# Altium schematic sheet EXT_IO.SchDoc(EXT_IO)
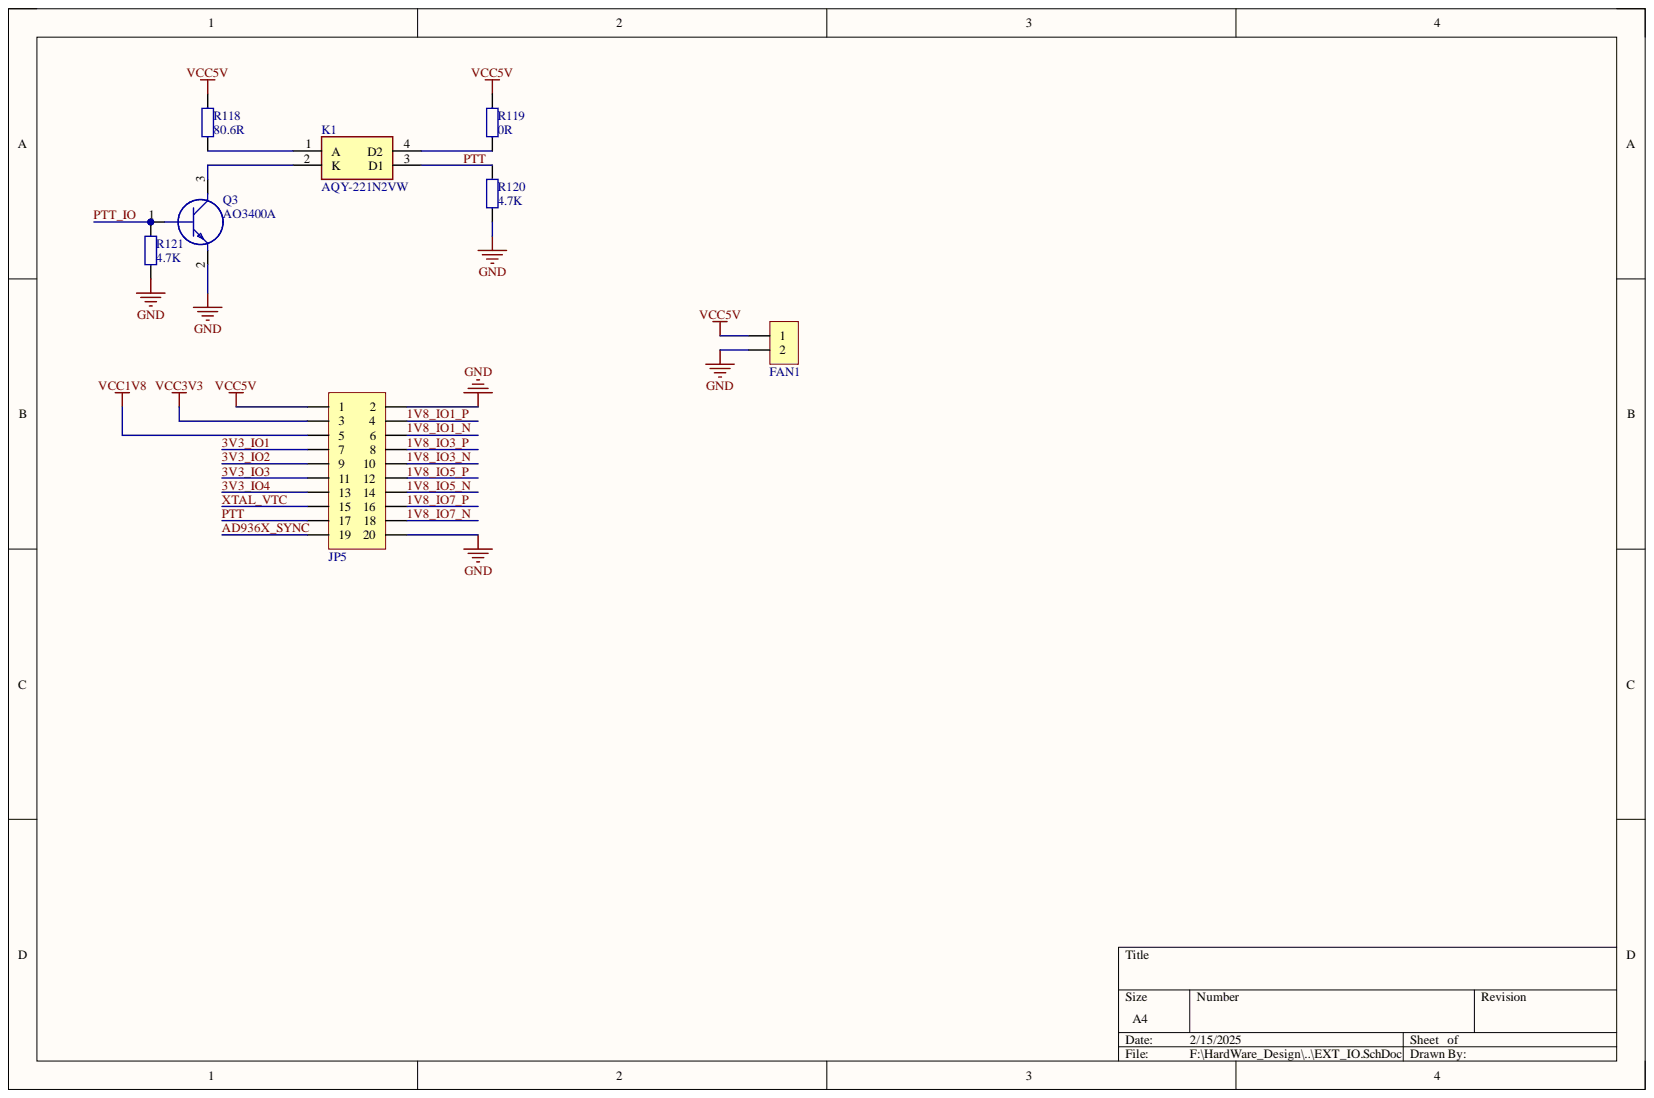
[diagram p0: EXT_IO.SchDoc]

## Parts
FAN1  HDR-1X2  [ZH1.5-LI-2p]  (value not drawn)
JP5  Header 2X10  [HDR2.54-LI-2x10P]  (value not drawn)
K1  AQY-221N2VW  [AQY221N2VW]
Q3  AO3400A  [SOT95P240X110-3N]
R118  80.6R  [R 0402_L]
R119  0R  [R 0402_L]
R120  4.7K  [R 0402_L]
R121  4.7K  [R 0402_L]

## Nets
GND: FAN1.2 JP5.2 JP5.20 Q3.2 R120.1 R121.1
VCC1V8: JP5.5
VCC3V3: JP5.3
VCC5V: FAN1.1 JP5.1 R118.2 R119.2
1V8_IO1_N: JP5.6
1V8_IO1_P: JP5.4
1V8_IO3_N: JP5.10
1V8_IO3_P: JP5.8
1V8_IO5_N: JP5.14
1V8_IO5_P: JP5.12
1V8_IO7_N: JP5.18
1V8_IO7_P: JP5.16
3V3_IO1: JP5.7
3V3_IO2: JP5.9
3V3_IO3: JP5.11
3V3_IO4: JP5.13
AD936X_SYNC: JP5.19
PTT: JP5.17 K1.3(D1) R120.2
PTT_IO: Q3.1 R121.2
XTAL_VTC: JP5.15
NetK1_1: K1.1(A) R118.1
NetK1_2: K1.2(K) Q3.3
NetK1_4: K1.4(D2) R119.1

## Library data of the parts
FAN1 = Header 2: 2P接插件; 版权归属: 封装库来自淘宝 ID：黄晶宝石; BOM名称: 2P接插件; Component Kind: Standard; 联系售后: https://shop463601444.taobao.com; Library Name: 2.54间距排针排母插件贴片.SchLib
JP5 = Header 10X2: 10*2P接插件; 版权归属: 源创客; BOM名称: 10*2P接插件; Component Kind: Standard; 学习资源: www.ooocke.com; Library Name: 2.54间距排针排母插件贴片.SchLib
K1 = AQY-221N2VW: Relay or Contactor; Manufacturer_Name: Panasonic; Component Kind: Standard; Datasheet Link: https://datasheet.datasheetarchive.com/originals/distributors/Datasheets-21/DSA-416273.pdf; Height: 1.9mm; Library Name: SamacSys.SchLib; Manufacturer_Part_Number: AQY-221N2VW
Q3 = S8050G: Transistor BJT NPN; Manufacturer_Name: Galaxy; Component Kind: Standard; Height: 1.1mm; Library Name: SamacSys.SchLib; Manufacturer_Part_Number: S8050G
R118-R121 = Res: 通用; Component Kind: Standard; Library Name: A_Schlib.SchLib
  R118: value: 80.6R
  R119: value: 0R
  R120 R121: value: 4.7K
